# Altium schematic sheet Programmer.SchDoc(Programmer)
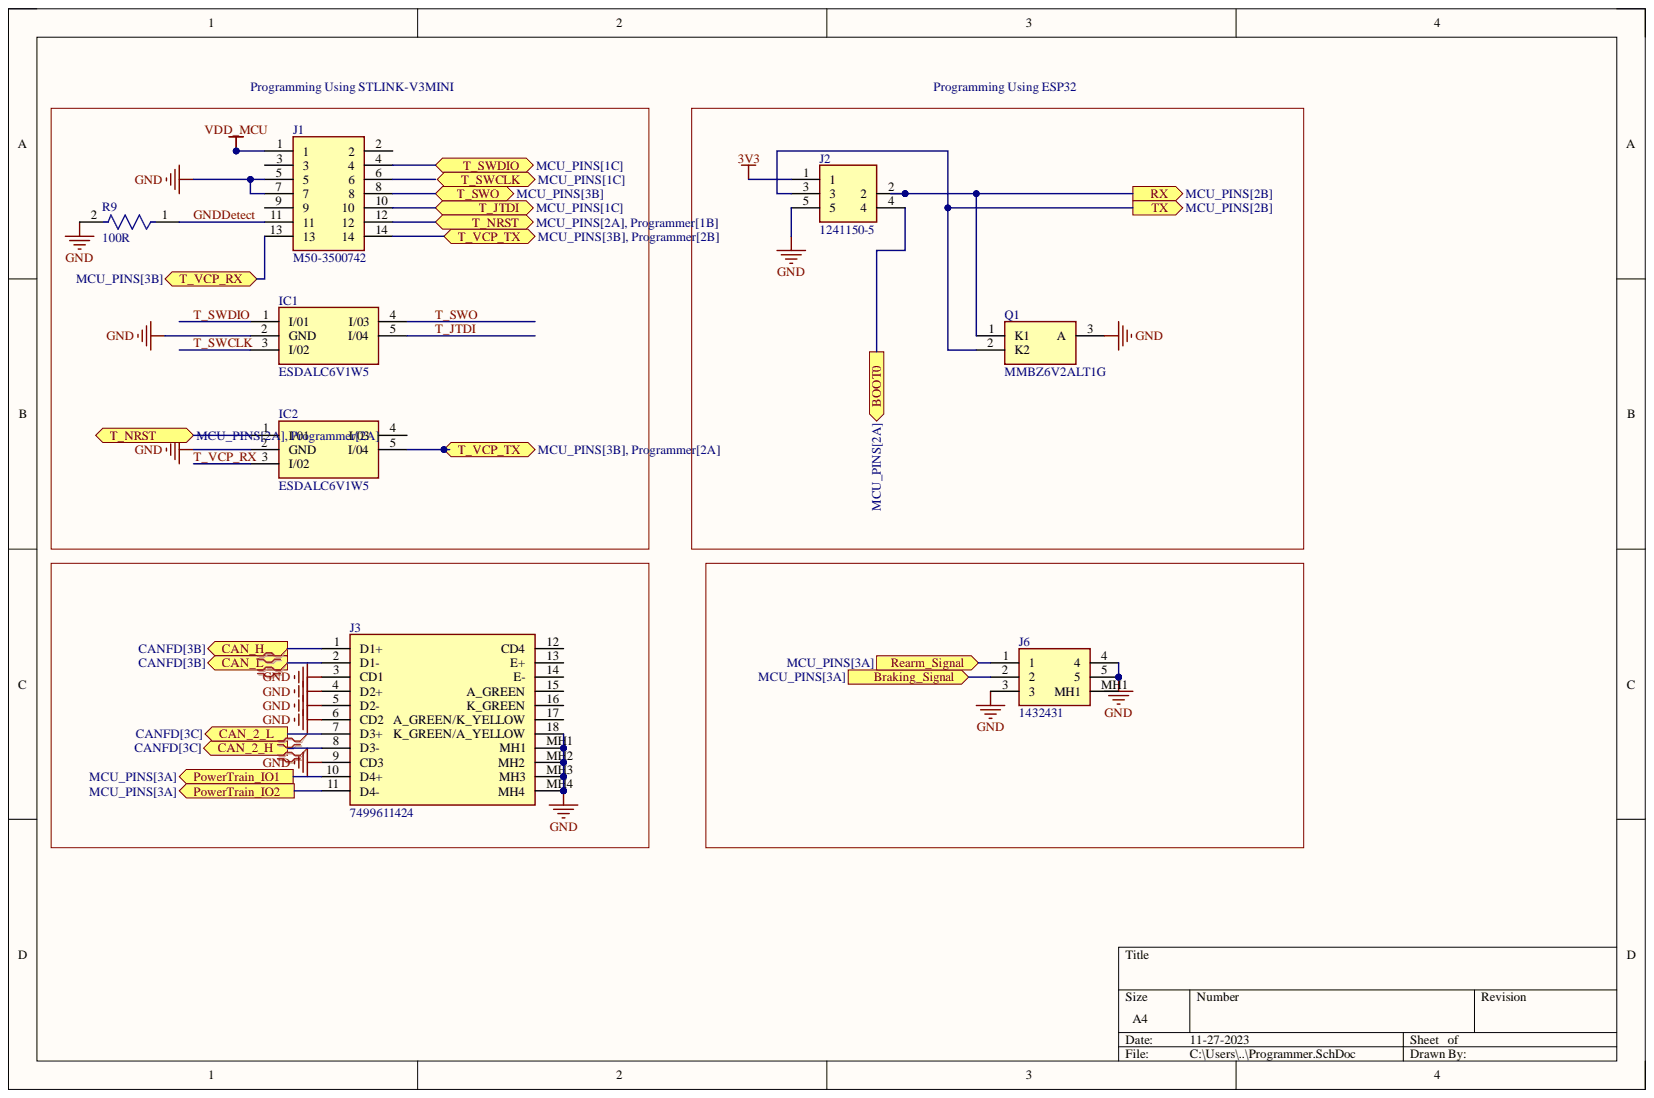
[diagram p0: Programmer.SchDoc]

## Parts
IC1  ESDALC6V1W5  [SOT65P210X110-5N]
IC2  ESDALC6V1W5  [SOT65P210X110-5N]
J1  M50-3500742  [M50-3500742]
J2  1241150-5  [12411505]
J3  7499611424  [7499611424]
J6  1432431  [1432431]
Q1  MMBZ6V2ALT1G  [SOT96P237X111-3N]
R9  100R  [FP-RT0603-MFG]

## Ports
BOOT0, Braking_Signal, CAN_2_H, CAN_2_L, CAN_H, CAN_L, PowerTrain_IO1, PowerTrain_IO2, Rearm_Signal, RX, T_JTDI, T_NRST, T_SWCLK, T_SWDIO, T_SWO, T_VCP_RX, T_VCP_TX, TX

## Nets
3V3: J2.1
GND: IC1.2(GND) IC2.2(GND) J1.5 J1.7 J2.5 J3.3(CD1) J3.4(D2+) J3.5(D2-) J3.6(CD2) J3.9(CD3) J3.18(K_GREEN/A_YELLOW) J3.MH1 J3.MH2 J3.MH3 J3.MH4 J6.3 J6.4 J6.5 Q1.3 R9.2
VDD_MCU: J1.1
BOOT0: J2.4 ; port BOOT0
Braking_Signal: J6.2 ; port Braking_Signal
CAN_2_H: J3.8(D3-) ; port CAN_2_H
CAN_2_L: J3.7(D3+) ; port CAN_2_L
CAN_H: J3.1(D1+) ; port CAN_H
CAN_L: J3.2(D1-) ; port CAN_L
GNDDetect: J1.11 R9.1
PowerTrain_IO1: J3.10(D4+) ; port PowerTrain_IO1
PowerTrain_IO2: J3.11(D4-) ; port PowerTrain_IO2
Rearm_Signal: J6.1 ; port Rearm_Signal
RX: J2.2 Q1.1 ; port RX
T_JTDI: IC1.5(I/04)
T_NRST: IC2.1(I/01) J1.12 ; port T_NRST
T_SWCLK: IC1.3(I/02)
T_SWDIO: IC1.1(I/01)
T_SWO: IC1.4(I/03)
T_VCP_RX: IC2.3(I/02)
T_VCP_TX: IC2.5(I/04) J1.14 ; port T_VCP_TX
TX: J2.3 Q1.2 ; port TX
NetJ1_4: J1.4 ; port T_SWDIO
NetJ1_8: J1.8 ; port T_SWO
NetJ1_10: J1.10 ; port T_JTDI
NetJ1_13: J1.13 ; port T_VCP_RX
N00001: ; port T_SWCLK

Not connected: IC2 4(Programmer[2A]); J1 2 3 6 9; J3 12(CD4) 13(E+) 14(E-) 15(A_GREEN) 16(K_GREEN) 17(A_GREEN/K_YELLOW); J6 MH1

## Library data of the parts
IC1 IC2 = ESDALC6V1W5: Integrated Circuit; Manufacturer_Name: STMicroelectronics; Arrow Part Number: ESDALC6V1W5; Arrow Price/Stock: https://www.arrow.com/en/products/esdalc6v1w5/stmicroelectronics?region=nac; Component Kind: Standard; Datasheet Link: http://www.st.com/web/en/resource/technical/document/datasheet/CD00002946.pdf; Height: 1.1mm; Manufacturer_Part_Number: ESDALC6V1W5; Mouser Part Number: 511-ESDALC6V1W5; Mouser Price/Stock: https://www.mouser.co.uk/ProductDetail/STMicroelectronics/ESDALC6V1W5?qs=C%2FEejvvdwQ4xcyFnfrFaDw%3D%3D
J1 = M50-3500742: Connector; Manufacturer_Name: Harwin; Component Kind: Standard; Datasheet Link: https://cdn.harwin.com/pdfs/M50-350.pdf; Manufacturer_Part_Number: M50-3500742; Mouser Part Number: 855-M50-3500742; Mouser Price/Stock: https://www.mouser.co.uk/ProductDetail/Harwin/M50-3500742?qs=9fQaSFfsqszsmDwu5uh%252BcA%3D%3D
J2 = 1241150-5: Connector; Manufacturer_Name: TE Connectivity; Component Kind: Standard; Datasheet Link: https://www.te.com/commerce/DocumentDelivery/DDEController?Action=srchrtrv&DocNm=1307819_AMPMODU&DocType=Data+Sheet&DocLang=English&PartCntxt=1241150-5&DocFormat=pdf; Height: 12mm; Manufacturer_Part_Number: 1241150-5; Mouser Part Number: 571-1241150-5; Mouser Price/Stock: https://www.mouser.co.uk/ProductDetail/TE-Connectivity/1241150-5?qs=qfkmxCJ1OTNnDOv%2FvINfMg%3D%3D
J3 = 7499611424: Connector; Manufacturer_Name: Wurth Elektronik; Component Kind: Standard; Datasheet Link: https://www.we-online.de/katalog/datasheet/7499611424.pdf; Height: 11.55mm; Manufacturer_Part_Number: 7499611424; Mouser Part Number: 710-7499611424; Mouser Price/Stock: https://www.mouser.co.uk/ProductDetail/Wurth-Elektronik/7499611424?qs=ljCeji4nMDlfhtZnezZNfA%3D%3D
J6 = 1432431: Connector; Manufacturer_Name: Phoenix Contact; Component Kind: Standard; Datasheet Link: http://www.phoenixcontact.com/de/produkte/1432431; Height: 15.9mm; Manufacturer_Part_Number: 1432431; Mouser Part Number: 651-1432431; Mouser Price/Stock: https://www.mouser.co.uk/ProductDetail/Phoenix-Contact/1432431?qs=yVWAs5b1x8PZHl9NAHm63A%3D%3D
Q1 = MMBZ6V2ALT1G: Transistor; Manufacturer_Name: onsemi; Arrow Part Number: MMBZ6V2ALT1G; Arrow Price/Stock: https://www.arrow.com/en/products/mmbz6v2alt1g/on-semiconductor; Component Kind: Standard; Datasheet Link: http://www.onsemi.com/pub/Collateral/MMBZ5V6ALT1-D.PDF; Height: 1.11mm; Manufacturer_Part_Number: MMBZ6V2ALT1G; Mouser Part Number: 863-MMBZ6V2ALT1G; Mouser Price/Stock: https://www.mouser.co.uk/ProductDetail/ON-Semiconductor/MMBZ6V2ALT1G?qs=P4IOph%252Bbot9qFNV0f0djAg%3D%3D
R9 = CMP-030-000001-1: RES SMD 10K OHM 1% 1/10W 0603; Manufacturer: Yageo; Manufacturer Part Number: RT0603FRE0710KL; Power Rating: 0.1W; Resistance: 10KO; Temperature Coefficient: 50 ppm/°C; Tolerance: 0.01; Value: 10KO; Voltage Rating: 75V; Automotive: No; Category: Res; Component Kind: Standard; Component Type: Standard; Composition: Thin Film; Design Item ID: CMP-03412-005349-1; Device Class L1: Passive Components; Device Class L2: Resistors; Device Class L3: Chip SMD Resistors; Digikey Description: RES SMD 10K OHM 1% 1/10W 0603; Footprint Url: http://www.yageo.com/exep/pages/download/literatures/PYu-R_Mount_10.pdf; Height: 0.55mm; Ipc Land Pattern Name: RESC16080X45; Lead Free: Yes; Library Name: DH08; Mouser Description: Thin Film Resistors - SMD 10K ohm 1% 1/10W; Octopart: https://octopart.com/rt0603fre0710kl-yageo-40009243; Package: RT0603; Pin Count: 2; RoHS: TRUE; Series: RT; Temperature: -55°C to +155°C; Temperature Range High: +155°C; Temperature Range Low: -55°C; Source: DH07_Optical_Localization_Sensor_V2_Main_1.SCHLIB
